# Altium schematic sheet 底板.SchDoc
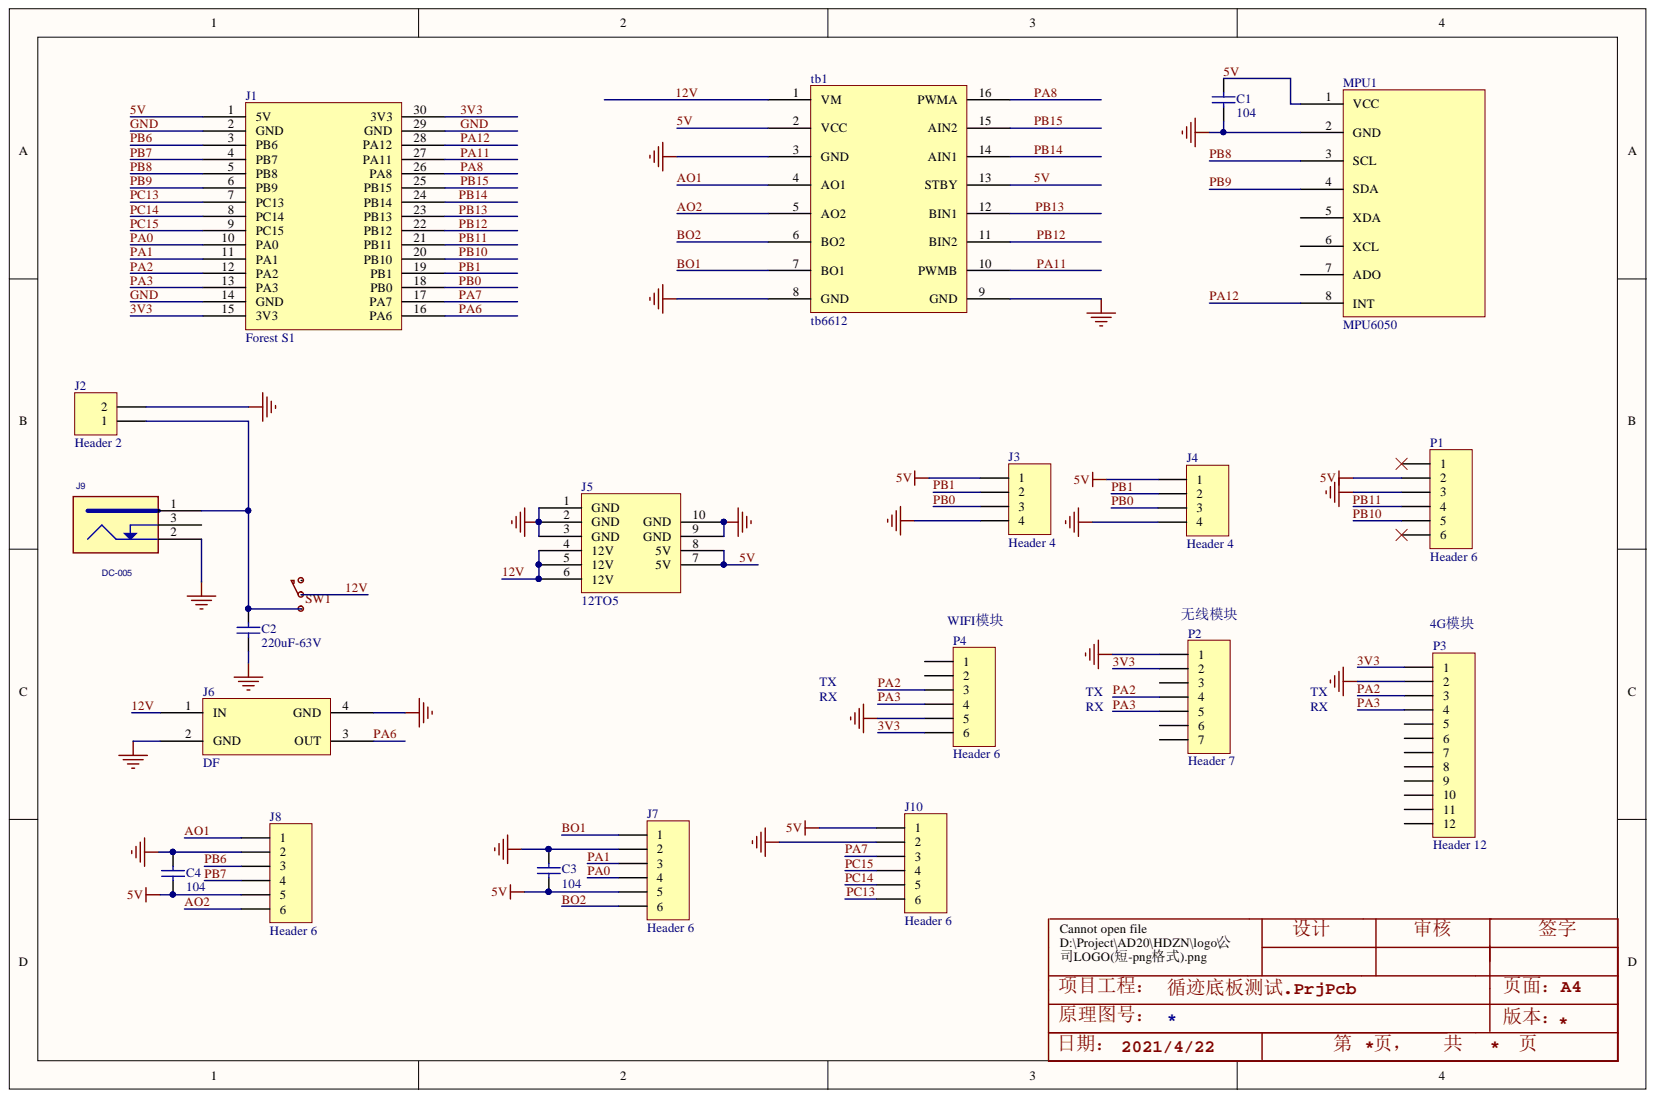
[diagram p0: 底板.SchDoc]

## Parts
C1  104  [Cap-CP]
C2  220uF-63V  [CAP-820uF-6.3V]
C3  104  [Cap-CP]
C4  104  [Cap-CP]
J1  Forest S1  [Forest S1]
J2  Header 2  [PHOENIX_1757242]
J3  Header 4  [HDR1X4]
J4  Header 4  [HDR1X4]
J5  12TO5  [稳压12T05]
J6  DF  [DF - 3]
J7  Header 6  [XH2.54-WI-6P]
J8  Header 6  [XH2.54-WI-6P]
J9  DC-005  [PJ-058A]
J10  Header 6  [XH2.54-WI-6P]
MPU1  MPU6050  [6050new]
P1  Header 6  [HDR1X6 - XH弯]
P2  Header 7  [HDR2.54-M-LI-7P]
P3  Header 12  [HDR2.54-M-LI-12P]
P4  Header 6  [HDR2.54-M-LI-6P]
SW1  SWITCH  [SS12d11拨动电源开关]  (value not drawn)
tb1  tb6612  [TB6612DIP]

## Nets
3V3: J1.15(3V3) J1.30(3V3) P2.2 P3.1 P4.6
5V: C1.1 C3.2 C4.2 J1.1(5V) J3.1 J4.1 J5.7(5V) J5.8(5V) J7.5 J8.5 J10.1 MPU1.1(VCC) P1.2 tb1.2(VCC) tb1.13(STBY)
12V: J5.4(12V) J5.5(12V) J5.6(12V) J6.1(IN) SW1.2 tb1.1(VM)
AO1: J8.1 tb1.4(AO1)
AO2: J8.6 tb1.5(AO2)
BO1: J7.1 tb1.7(BO1)
BO2: J7.6 tb1.6(BO2)
GND: C1.2 C2.2 C3.1 C4.1 J1.2(GND) J1.14(GND) J1.29(GND) J2.2 J3.4 J4.4 J5.1(GND) J5.2(GND) J5.3(GND) J5.9(GND) J5.10(GND) J6.2(GND) J6.4(GND) J7.2 J8.2 J9.2 J10.2 MPU1.2(GND) P1.3 P2.1 P3.2 P4.5 tb1.3(GND) tb1.8(GND) tb1.9(GND)
PA0: J1.10(PA0) J7.4
PA1: J1.11(PA1) J7.3
PA2: J1.12(PA2) P2.4 P3.3 P4.3
PA3: J1.13(PA3) P2.5 P3.4 P4.4
PA6: J1.16(PA6) J6.3(OUT)
PA7: J1.17(PA7) J10.3
PA8: J1.26(PA8) tb1.16(PWMA)
PA11: J1.27(PA11) tb1.10(PWMB)
PA12: J1.28(PA12) MPU1.8(INT)
PB0: J1.18(PB0) J3.3 J4.3
PB1: J1.19(PB1) J3.2 J4.2
PB6: J1.3(PB6) J8.3
PB7: J1.4(PB7) J8.4
PB8: J1.5(PB8) MPU1.3(SCL)
PB9: J1.6(PB9) MPU1.4(SDA)
PB10: J1.20(PB10) P1.5
PB11: J1.21(PB11) P1.4
PB12: J1.22(PB12) tb1.11(BIN2)
PB13: J1.23(PB13) tb1.12(BIN1)
PB14: J1.24(PB14) tb1.14(AIN1)
PB15: J1.25(PB15) tb1.15(AIN2)
PC13: J1.7(PC13) J10.6
PC14: J1.8(PC14) J10.5
PC15: J1.9(PC15) J10.4
NetC2_1: C2.1 J2.1 J9.1 SW1.1

Not connected: J9 3; MPU1 5(XDA) 6(XCL) 7(ADO); P1 1 6; P2 3 6 7; P3 5 6 7 8 9 10 11 12; P4 1 2; SW1 3

## Library data of the parts
C1-C4 = Cap: 贴片电容; Component Kind: Standard; LatestRevisionDate: 17-Jul-2002; LatestRevisionNote: Re-released for DXP Platform.; Library Name: Miscellaneous Devices.IntLib; PackageReference: RAD-0.3; Published: 8-Jun-2000; Signal Integrity: Cap; Simulation: CAP; Publisher: Altium Limited
J2 = Header 2: Header, 2-Pin; Component Kind: Standard; LatestRevisionDate: 17-Jul-2002; LatestRevisionNote: Re-released for DXP Platform.; Library Name: Miscellaneous Connectors.IntLib; Signal Integrity: Connector; Publisher: Altium Limited
J3 J4 = Header 4: Header, 4-Pin; Component Kind: Standard; LatestRevisionDate: 17-Jul-2002; LatestRevisionNote: Re-released for DXP Platform.; Library Name: Miscellaneous Connectors.IntLib; Signal Integrity: Connector; Publisher: Altium Limited
J7 J8 J10 P1 P4 = Header 6: Header, 6-Pin; Component Kind: Standard; LatestRevisionDate: 17-Jul-2002; LatestRevisionNote: Re-released for DXP Platform.; Library Name: Miscellaneous Connectors.IntLib; Signal Integrity: Connector; Publisher: Altium Limited
J9 = DC-005: Remark: DC-005电源接口,90度; room: 4; Component Kind: Standard
P2 = Header 7: Header, 7-Pin; Component Kind: Standard; LatestRevisionDate: 17-Jul-2002; LatestRevisionNote: Re-released for DXP Platform.; Library Name: Miscellaneous Connectors.IntLib; Signal Integrity: Connector; Publisher: Altium Limited
P3 = Header 12: Header, 12-Pin; Component Kind: Standard; LatestRevisionDate: 17-Jul-2002; LatestRevisionNote: Re-released for DXP Platform.; Library Name: Miscellaneous Connectors.IntLib; Signal Integrity: Connector; Publisher: Altium Limited
SW1 = SWITCH: SPDT, Thru Hole, Slide Switch; Component Kind: Standard; Library Name: Digilent.IntLib
J1 = Forest S1: Component Kind: Standard; Library Name: Schlib1.SchLib
J5 = 12TO5: Component Kind: Standard; Library Name: Schlib1.SchLib
J6 = DF: Component Kind: Standard; Library Name: Schlib1.SchLib
MPU1 = MPU6050: Component Kind: Standard; Library Name: Schlib1.SchLib
tb1 = tb6612: Component Kind: Standard; Library Name: Schlib1.SchLib
